# Altium schematic sheet Filtr3.SchDoc
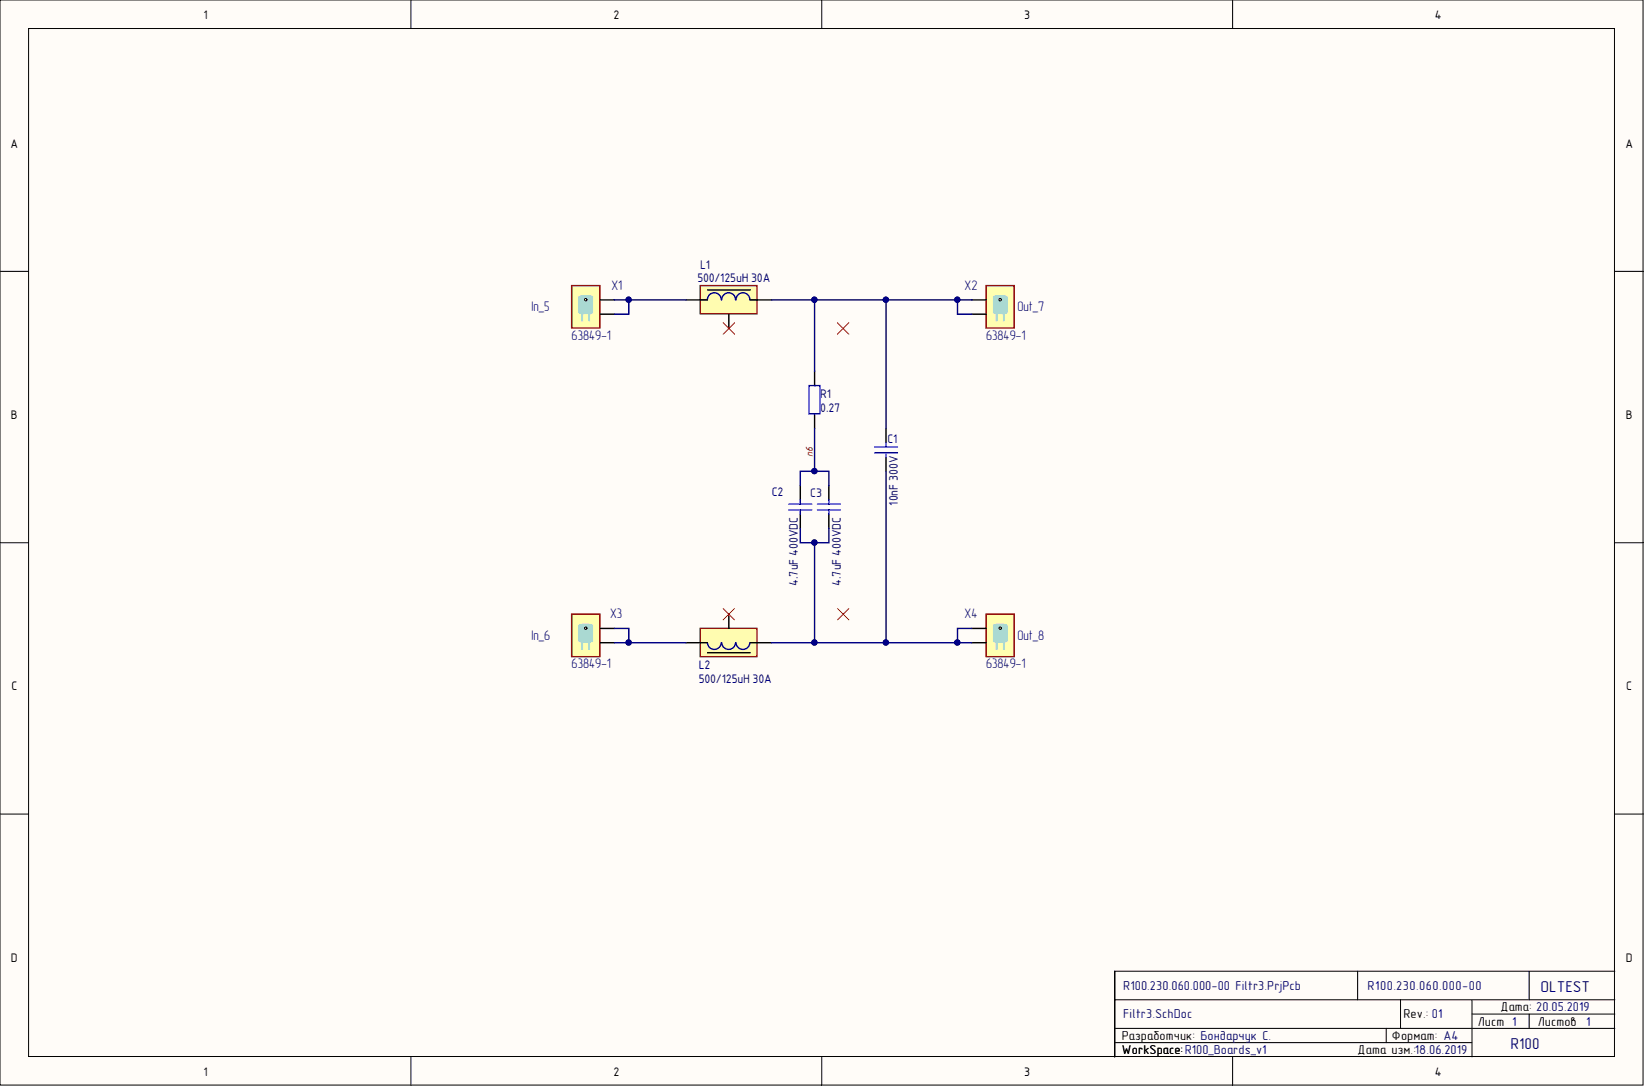
[diagram p0: Filtr3.SchDoc]

## Parts
C1  10nF 300V  [C Y2 D13 7.5b]
C2  4.7uF 400VDC  [MKP_W20_H39.5_L41.5_LS37.5]
C3  4.7uF 400VDC  [MKP_W20_H39.5_L41.5_LS37.5]
L1  500/125uH 30A  [Dross (500/125 uH 30 A)AMAK.671111.034]
L2  500/125uH 30A  [Dross (500/125 uH 30 A)AMAK.671111.034]
R1  0.27  [PRW 5W 1050]
X1  63849-1  [63849-1+insulator(154719-1)]
X2  63849-1  [63849-1+insulator(154719-1)]
X3  63849-1  [63849-1+insulator(154719-1)]
X4  63849-1  [63849-1+insulator(154719-1)]

## Nets
N6: C2.2 C3.2 R1.1
NetC1_1: C1.1 C2.1 C3.1 L2.1 X4.1 X4.2
NetC1_2: C1.2 L1.2 R1.2 X2.1 X2.2
NetL1_1: L1.1 X1.1 X1.2
NetL2_2: L2.2 X3.1 X3.2

Not connected: L1 3; L2 3

## Library data of the parts
C1 = C X1Y2 10nF D13 LS7.5: Ceramic D=14 LS=7.5 300VAC X1Y2; Manufacturer: Murata; PartNumber: DE2F3KY103MA3; Component Kind: Standard; Library Name: mainRCLib.SchLib; Value: 10nF 300V
C2 C3 = MKP1 4.7uF 10% 400VDC: Polypropelene W20 H39.5 L41.5 LS37.5 400VDC 10%; Manufacturer: Wima; PartNumber: MKP1G044707G00K; Component Kind: Standard; Library Name: mainRCLib.SchLib; Value: 4.7uF 400VDC
L1 L2 = Dross 500/125uH 30A АМАК.671111.034: 500/125uH 30A  (Core T250-52 X1); Manufacturer: OLTEST; Component Kind: Standard; Library Name: mainLib.SchLib; PartNumber: АМАК.671111.034; Value: 500/125uH 30A
R1 = PRW 0.27 5W +-5%: Выводной PRW 5W 0R27 +-5%; Manufacturer: RoyalOhm; PartNumber: PRW05WJW27K; Component Kind: Standard; Library Name: mainRCLib.SchLib; Value: 0.27
X1-X4 = 63849-1: PCB, 6.3х0.8, hole; Manufacturer: TE Connectivity; Component Kind: Standard; Library Name: mainLib.SchLib; PartNumber: 63849-1
